# Altium schematic sheet Measure.SchDoc
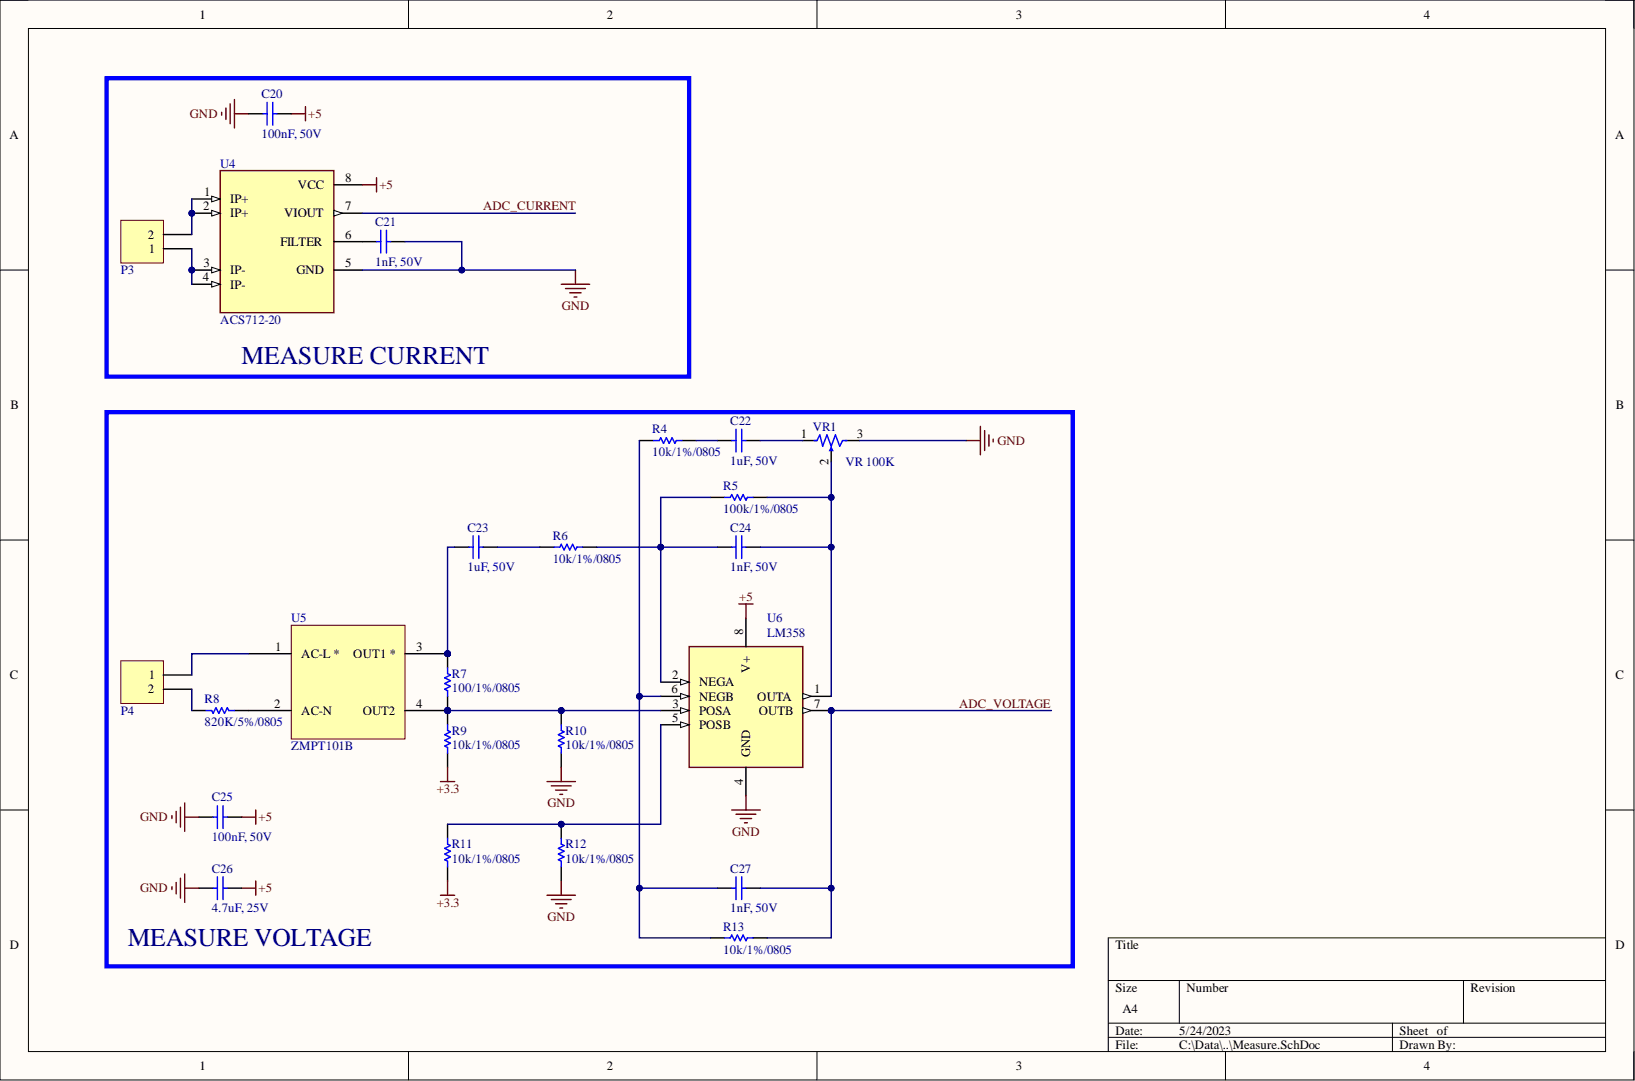
[diagram p0: Measure.SchDoc]

## Parts
C20  100nF, 50V  [WCAP-CSGP_0805_H=0.8mm_Wave]
C21  1nF, 50V  [WCAP-CSGP_0805_H=0.8mm_Wave]
C22  1uF, 50V  [WCAP-CSGP_0805_H=1.25mm]
C23  1uF, 50V  [WCAP-CSGP_0805_H=1.25mm]
C24  1nF, 50V  [WCAP-CSGP_0805_H=0.8mm_Wave]
C25  100nF, 50V  [WCAP-CSGP_0805_H=0.8mm_Wave]
C26  4.7uF, 25V  [WCAP-CSGP_0805_H=1.25mm]
C27  1nF, 50V  [WCAP-CSGP_0805_H=0.8mm_Wave]
P3  Domino 2  [DOMINO 2- 5mm]  (value not drawn)
P4  Domino 2  [DOMINO 2- 5mm]  (value not drawn)
R4  value 10k/1%/0805  [RES-SM-0805]  (hidden comment: RESISTOR SMD)
R5  value 100k/1%/0805  [RES-SM-0805]  (hidden comment: RESISTOR SMD)
R6  value 10k/1%/0805  [RES-SM-0805]  (hidden comment: RESISTOR SMD)
R7  value 100/1%/0805  [RES-SM-0805]  (hidden comment: RESISTOR SMD)
R8  value 820K/5%/0805  [RES-SM-0805]  (hidden comment: RESISTOR SMD)
R9  value 10k/1%/0805  [RES-SM-0805]  (hidden comment: RESISTOR SMD)
R10  value 10k/1%/0805  [RES-SM-0805]  (hidden comment: RESISTOR SMD)
R11  value 10k/1%/0805  [RES-SM-0805]  (hidden comment: RESISTOR SMD)
R12  value 10k/1%/0805  [RES-SM-0805]  (hidden comment: RESISTOR SMD)
R13  value 10k/1%/0805  [RES-SM-0805]  (hidden comment: RESISTOR SMD)
U4  ACS712-20  [SOIC127P600X175-8N]
U5  ZMPT101B  [ZMPT101B]
U6  LM358  [SOIC-8]
VR1  value VR 100K  [VARISTOR 2]  (hidden comment: 10K)

## Nets
+3.3: R9.1 R11.1
+5: C20.2 C25.2 C26.2 U4.8(VCC) U6.8(V+)
GND: C20.1 C21.2 C25.1 C26.1 R10.1 R12.1 U4.5(GND) U6.4(GND) VR1.3
ADC_CURRENT: U4.7(VIOUT)
ADC_VOLTAGE: C27.2 R13.1 U6.7(OUTB)
NetC21_1: C21.1 U4.6(FILTER)
NetC22_1: C22.1 R4.1
NetC22_2: C22.2 VR1.1
NetC23_1: C23.1 R7.1 U5.3(OUT1_*)
NetC23_2: C23.2 R6.1
NetC24_1: C24.1 R5.1 R6.2 U6.2(NEGA)
NetC24_2: C24.2 R5.2 U6.1(OUTA) VR1.2
NetC27_1: C27.1 R4.2 R13.2 U6.6(NEGB)
NetP3_1: P3.1 U4.3(IP-) U4.4(IP-)
NetP3_2: P3.2 U4.1(IP+) U4.2(IP+)
NetP4_1: P4.1 U5.1(AC-L_*)
NetP4_2: P4.2 R8.2
NetR7_2: R7.2 R9.2 R10.2 U5.4(OUT2) U6.3(POSA)
NetR8_1: R8.1 U5.2(AC-N)
NetR11_2: R11.2 R12.2 U6.5(POSB)

## Library data of the parts
C20 C25 = 885012207098: WCAP-CSGP Multilayer Ceramic Chip Capacitor, General Purpose, size 0805, X7R, 100nF, 50V; Manufacturer: Wurth Elektronik; Capacitance Tolerance: +/-10%; Dissipation Factor: #NAME?; Isolation Resistance: >=5GOhm; Rated Voltage: 50VDC; Technical Reference: X7R0805104K050DFCT10000; Capacitance: 100nF; Ceramic Type: X7R; Component Kind: Standard; ComponentLink1Description: Manufacturer; ComponentLink1URL: http://www.we-online.de; ComponentLink2Description: Datasheet; ComponentLink2URL: https://www.we-online.com/catalog/datasheet/885012207098.pdf; Height: 0.8mm; Library Name: Altium_WCAP-CSGP (rev20a).IntLib; Manufacturer Part Number: 885012207098; Mounting Technology: SMT; Operating Temperature: -55 to +125degC; Packaging: Tape and Reel; Published Date: May 2020; Size: 0805
C21 C24 C27 = 885012007063: WCAP-CSGP Multilayer Ceramic Chip Capacitor, General Purpose, size 0805, NP0, 1nF, 50V; Manufacturer: Wurth Elektronik; Capacitance Tolerance: +/-5%; Dissipation Factor: Q>=1000; Isolation Resistance: >=10GOhm; Rated Voltage: 50VDC; Technical Reference: NP00805102J050DFCT10000; Capacitance: 1nF; Ceramic Type: NP0; Component Kind: Standard; ComponentLink1Description: Manufacturer; ComponentLink1URL: http://www.we-online.de; ComponentLink2Description: Datasheet; ComponentLink2URL: https://www.we-online.com/catalog/datasheet/885012007063.pdf; Height: 0.8mm; Library Name: Altium_WCAP-CSGP (rev20a).IntLib; Manufacturer Part Number: 885012007063; Mounting Technology: SMT; Operating Temperature: -55 to +125degC; Packaging: Tape and Reel; Published Date: May 2020; Size: 0805
C22 C23 = 885012207103: WCAP-CSGP Multilayer Ceramic Chip Capacitor, General Purpose, size 0805, X7R, 1uF, 50V; Manufacturer: Wurth Elektronik; Capacitance Tolerance: +/-10%; Dissipation Factor: #NAME?; Isolation Resistance: >=0.1GOhm; Rated Voltage: 50VDC; Technical Reference: X7R0805105K050DFCT10000; Capacitance: 1uF; Ceramic Type: X7R; Component Kind: Standard; ComponentLink1Description: Manufacturer; ComponentLink1URL: http://www.we-online.de; ComponentLink2Description: Datasheet; ComponentLink2URL: https://www.we-online.com/catalog/datasheet/885012207103.pdf; Height: 1.25mm; Library Name: Altium_WCAP-CSGP (rev20a).IntLib; Manufacturer Part Number: 885012207103; Mounting Technology: SMT; Operating Temperature: -55 to +125degC; Packaging: Tape and Reel; Published Date: May 2020; Size: 0805
C26 = 885012107018: WCAP-CSGP Multilayer Ceramic Chip Capacitor, General Purpose, size 0805, X5R, 4.7uF, 25V; Manufacturer: Wurth Elektronik; Capacitance Tolerance: +/-20%; Dissipation Factor: #NAME?; Isolation Resistance: >=0.02GOhm; Rated Voltage: 25VDC; Technical Reference: X5R0805475M025DFCT10000; Capacitance: 4.7uF; Ceramic Type: X5R; Component Kind: Standard; ComponentLink1Description: Manufacturer; ComponentLink1URL: http://www.we-online.de; ComponentLink2Description: Datasheet; ComponentLink2URL: https://www.we-online.com/catalog/datasheet/885012107018.pdf; Height: 1.25mm; Library Name: Altium_WCAP-CSGP (rev20a).IntLib; Manufacturer Part Number: 885012107018; Mounting Technology: SMT; Operating Temperature: -55 to +85degC; Packaging: Tape and Reel; Published Date: May 2020; Size: 0805
P3 P4 = Domino 2: Domino, 2-Pin; Component Kind: Standard; LatestRevisionDate: 17-Jul-2002; LatestRevisionNote: Re-released for DXP Platform.; Library Name: PIF_Library.IntLib; Publisher: Altium Limited
R4-R13 = RESISTOR SMD: Resistor; Component Kind: Standard; Library Name: PIF_Library.IntLib
U4 = ACS712-20: ACS712: IC de sensor de corriente lineal basado en efecto Hall totalmente integrado con aislamiento de voltaje de 2,1 kV RMS y un conductor de corriente de baja resistencia; MF: Analog Devices; Availability: In Stock; Check_prices: https://www.snapeda.com/parts/ACS712-20/Analog+Devices/view-part/?ref=eda; Component Kind: Standard; Library Name: ACS712-20.IntLib; MP: ACS712-20; Package: None; Price: None; SnapEDA_Link: https://www.snapeda.com/parts/ACS712-20/Analog+Devices/view-part/?ref=snap
U5 = ZMPT101B: Minuscule 2mA/2mA Precision Voltage Transformer; Component Kind: Standard; Library Name: Giang's Libs.IntLib
VR1 = Var Resistor: Component Kind: Standard; Description: Var Resistor; Library Name: PIF_Library.IntLib
U6 = LM358: Component Kind: Standard; Library Name: Giang's Libs.IntLib
